# Altium schematic sheet TKN.SchDoc
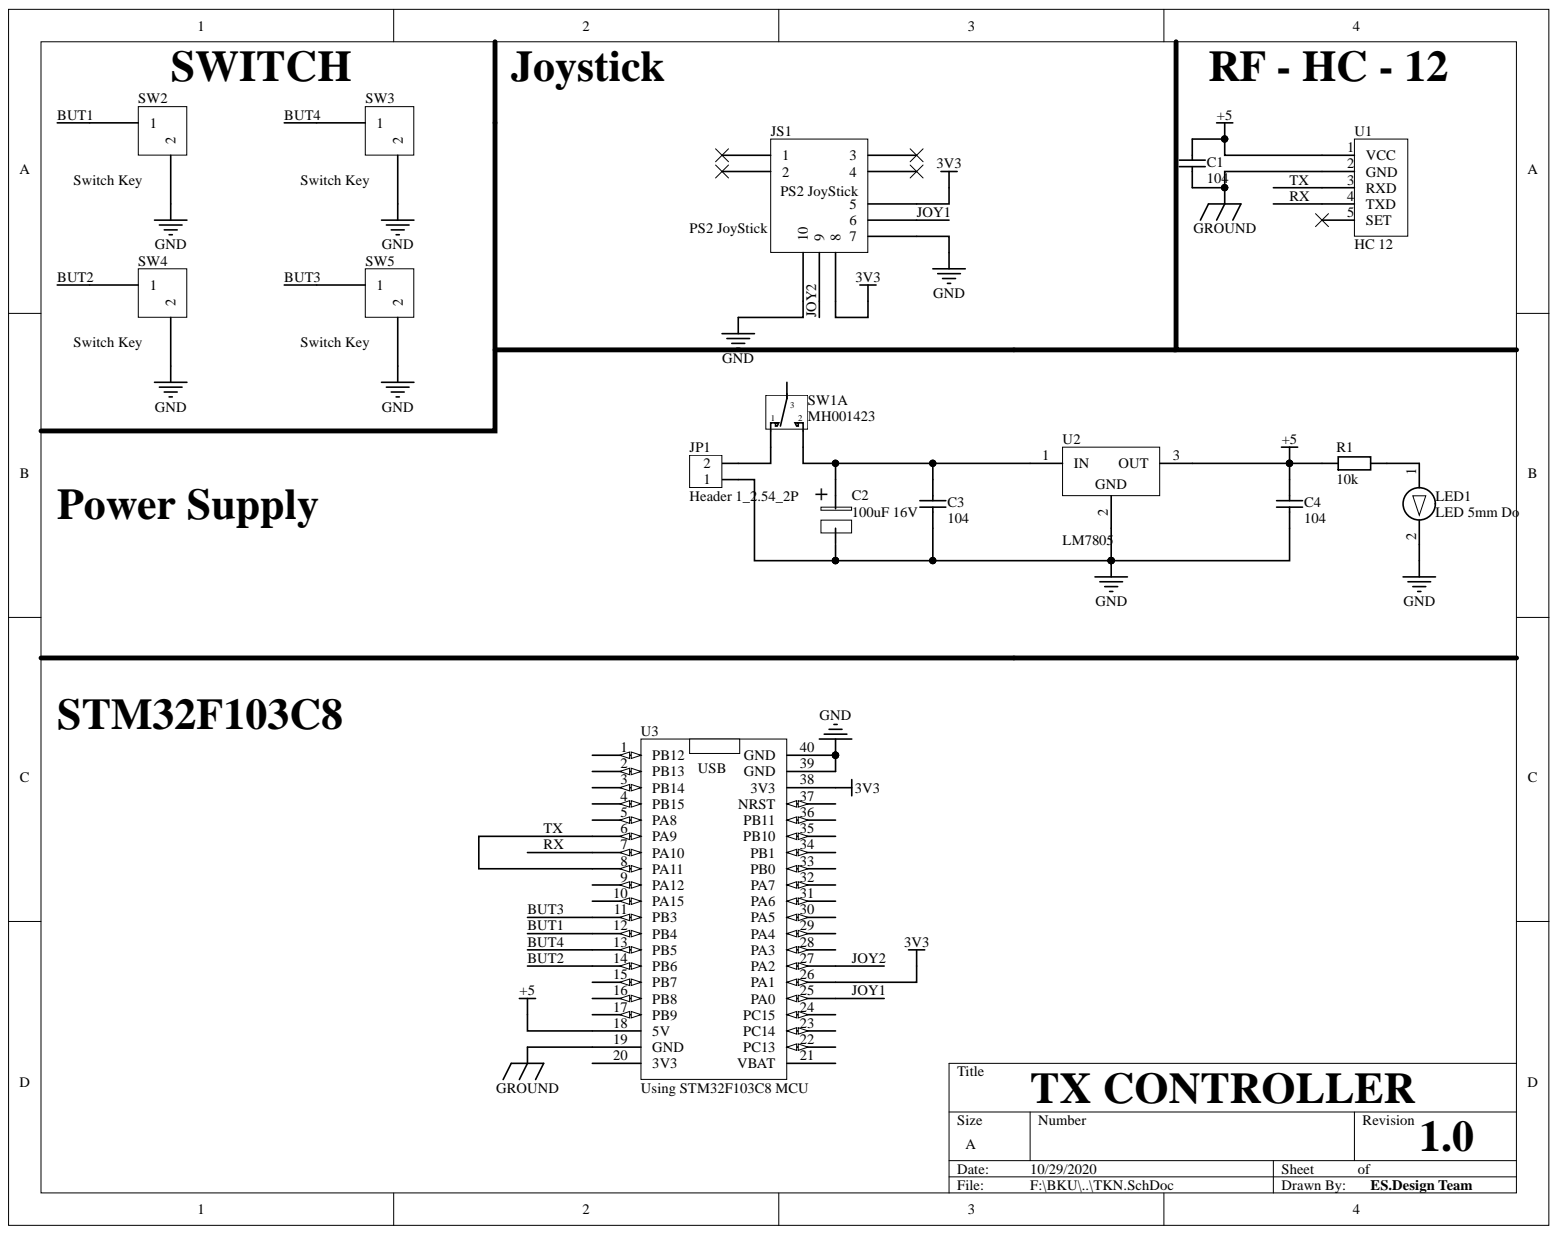
[diagram p0: TKN.SchDoc]

## Parts
C1  104  [tu 0805]
C2  100uF 16V  [TU HOA_2.5]
C3  104  [tu 0805]
C4  104  [tu 0805]
JP1  Header 1_2.54_2P  [Header_Duc Cong_2P 2.54]
JS1  PS2 JoyStick  [PS2 JoyStick]
LED1  LED 5mm Do  [LED 5mm DO]
R1  10k  [tro smd 0805]
SW1  MH001423  [SW6]  (part A)
SW2  Switch Key  [Switch Key]
SW3  Switch Key  [Switch Key]
SW4  Switch Key  [Switch Key]
SW5  Switch Key  [Switch Key]
U1  HC 12  [HC 12]
U2  LM7805  [DPAK254P1542X460-3M]
U3  Using STM32F103C8 MCU  [STM32 BluePill]

## Nets
3V3: JS1.5 JS1.8 U3.26(PA1) U3.38(3V3)
+5: C1.1 C4.1 R1.1 U1.1(VCC) U2.3 U3.18(5V)
GND: C2.2 C3.2 C4.2 JP1.1 JS1.7 JS1.10 LED1.2 SW2.2 SW3.2 SW4.2 SW5.2 U2.2 U3.39(GND) U3.40(GND)
GROUND: C1.2 U1.2(GND) U3.19(GND)
BUT1: SW2.1 U3.12(PB4)
BUT2: SW4.1 U3.14(PB6)
BUT3: SW5.1 U3.11(PB3)
BUT4: SW3.1 U3.13(PB5)
JOY1: JS1.6 U3.25(PA0)
JOY2: JS1.9 U3.27(PA2)
RX: U1.4(TXD) U3.7(PA10)
TX: U1.3(RXD) U3.6(PA9) U3.8(PA11)
NetC2_1: C2.1 C3.1 SW1.2 U2.1
NetJP1_2: JP1.2 SW1.1
NetLED1_1: LED1.1 R1.2

Not connected: JS1 1 2 3 4; SW1 3; U1 5(SET); U3 1(PB12) 2(PB13) 3(PB14) 4(PB15) 5(PA8) 9(PA12) 10(PA15) 15(PB7) 16(PB8) 17(PB9) 20(3V3) 21(VBAT) 22(PC13) 23(PC14) 24(PC15) 28(PA3) 29(PA4) 30(PA5) 31(PA6) 32(PA7) 33(PB0) 34(PB1) 35(PB10) 36(PB11) 37(NRST)

## Library data of the parts
C1 C3 C4 = Tu 0805 104 50V 10%: Dán; Don_Vi: Con; Ma_Hang: MH004551; So_Chan: 2; Ten_Linh_Kien: Tụ 0805 104-100nF 10% 50V; Chung_Loai: Dán; Component Kind: Standard; Library Name: THU VIEN SCH.SchLib
C2 = Tu 100uF 16V: Cắm; Chung_Loai: Dán; Don_Vi: Con; Ma_Hang: MH001347; Ten_Linh_Kien: Tụ Hóa 100uF 16V 5x7MM; Component Kind: Standard; Library Name: THU VIEN SCH.SchLib
JP1 = Header 1_2.54_2P: Cắm; Chung_Loai: Cắm; Component Kind: Standard; Library Name: THU VIEN SCH.SchLib
LED1 = LED 5mm Do: Cắm; Don_Vi: Con; Ma_Hang: MH004935; Ten_Linh_Kien: LED 3MM Xanh Lá ( SS); Chung_Loai: Cắm; Component Kind: Standard; Library Name: THU VIEN SCH.SchLib
R1 = Tro 0805 1% 10k: Dán; Don_Vi: Con; Ma_Hang: MH005473; So_Chan: 2; Ten_Linh_Kien: Trở 0805 1% 10k; Chung_Loai: Dán; Component Kind: Standard; Library Name: THU VIEN SCH.SchLib
SW1 = Nut Nhan 6 Chan  8x8MM ( Nhấn Giữ): Cắm; Don_Vi: Con; Ten_Linh_Kien: Nút Nhấn 6 Chân 8x8MM ( Nhấn Giữ); Chung_Loai: Cắm; Component Kind: Standard; Library Name: THU VIEN SCH.SchLib; Ma_Hang: MH001423
U2 = LM7805: Regulator 5v; Component Kind: Standard; Library Name: My_Lib.SchLib
U3 = BluePill: Component Kind: Standard; Description: Using STM32F103C8 MCU; Library Name: My_Lib.SchLib
JS1 = PS2 JoyStick: Component Kind: Standard; Library Name: My_Lib.SchLib
SW2-SW5 = Switch Key: Component Kind: Standard; Library Name: My_Lib.SchLib
U1 = HC 12: Component Kind: Standard; Library Name: My_Lib.SchLib
